# Altium schematic sheet Sensors.SchDoc
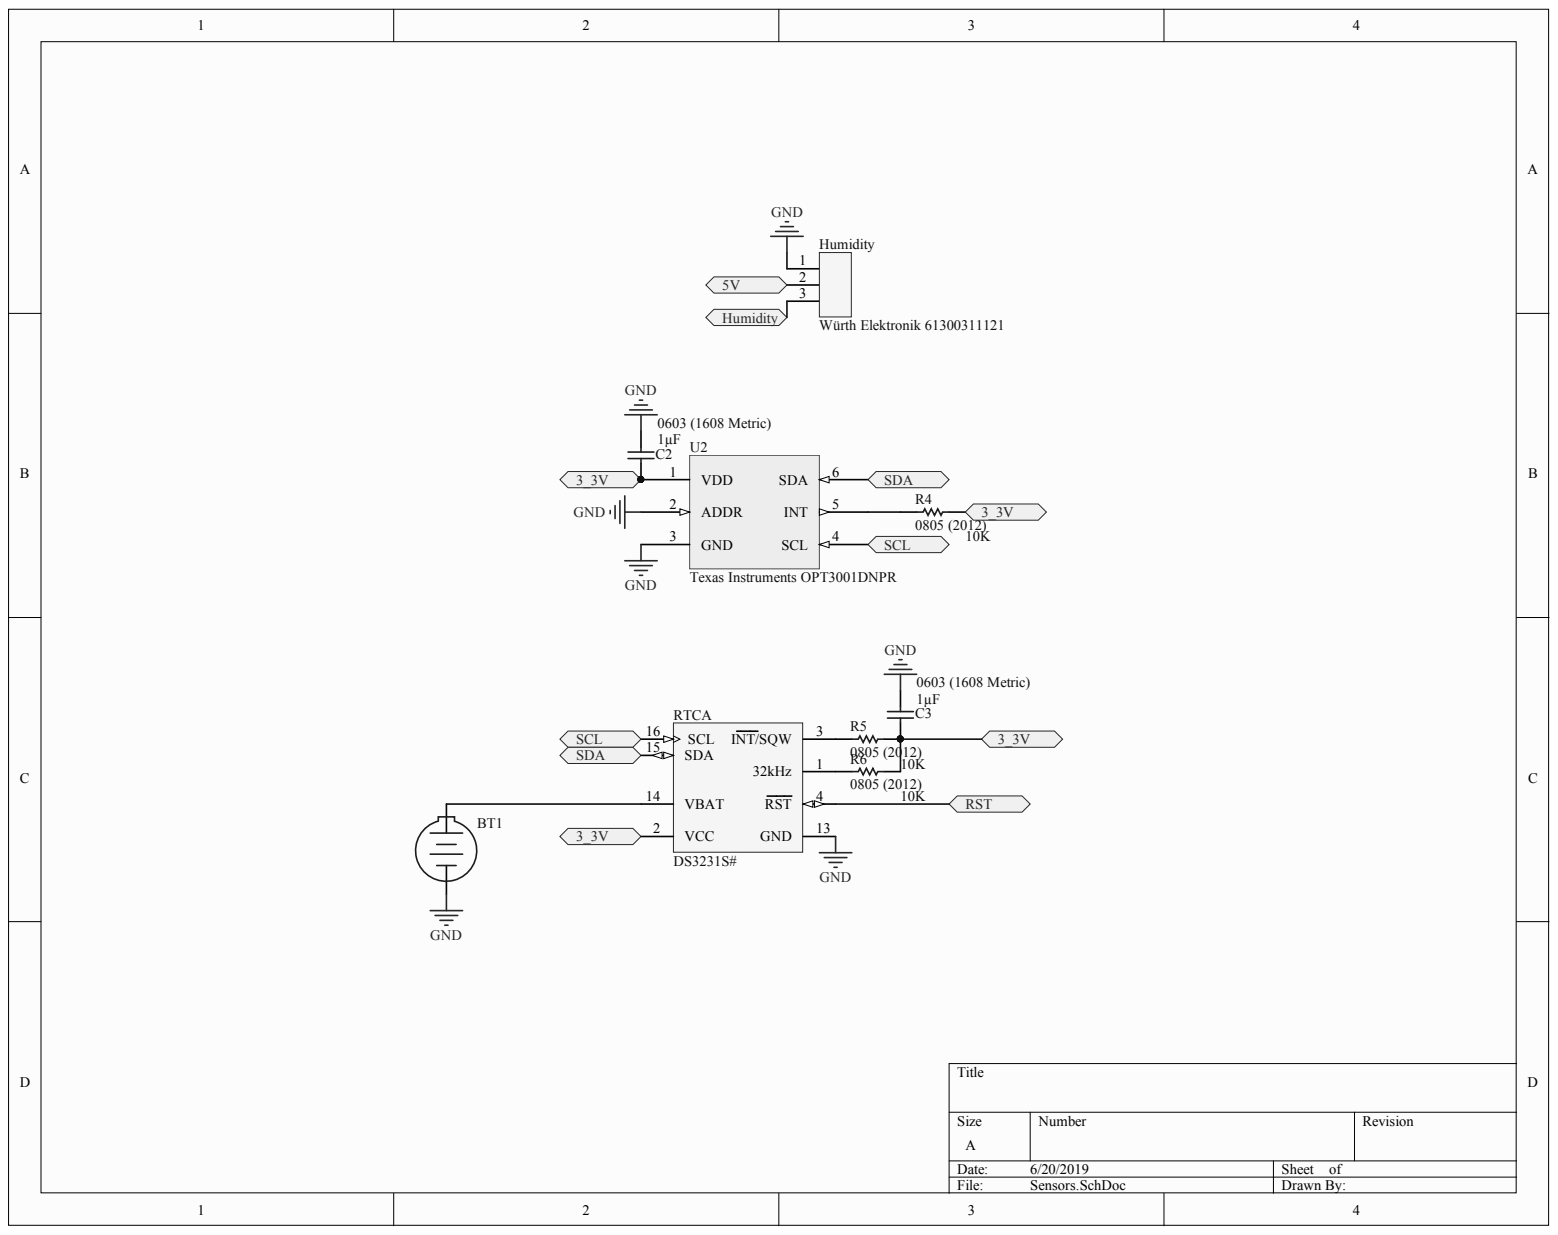
[diagram p0: Sensors.SchDoc]

## Parts
BT1  SYM-51b498be44848e73-1  [PCB-rukrbiajq2v9otngsupp-1]  (value not drawn)
C2  Capacitance: 1µF  [PCB-lkmleyt60a11wkc2qm7q-1]  (hidden comment: Samsung CL10A105KP8NNNC)
C3  Capacitance: 1µF  [PCB-lkmleyt60a11wkc2qm7q-1]  (hidden comment: Samsung CL10A105KP8NNNC)
Humidity  Würth Elektronik 61300311121  [PCB-4c070sxu9rgckamhssqs-1]
R4  0805 (2012)  Resistance: 10K  [PCB-m3d1bui9az782fo214h9-1]
R5  0805 (2012)  [PCB-m3d1bui9az782fo214h9-1]
R6  0805 (2012)  Resistance: 10K  [PCB-m3d1bui9az782fo214h9-1]
RTC  DS3231S#  [W16#H2_L]  (part A)
U2  Texas Instruments OPT3001DNPR  Manufacturer Part Number: OPT3001DNPR  [SOP6]

## Ports
3_3V, 5V, HUMIDITY, RST, SCL, SDA

## Nets
GND: BT1.2 C2.2 C3.2 Humidity.1 RTC.13(GND) U2.2(ADDR) U2.3(GND) U2.7
HUMIDITY: Humidity.3 ; port HUMIDITY
3_3V: C2.1 C3.1 R4.2 R5.2 R6.2 RTC.2(VCC) U2.1(VDD) ; port 3_3V
5V: Humidity.2 ; port 5V
RST: RTC.4(RST) ; port RST
SCL: RTC.16(SCL) U2.4(SCL) ; port SCL
SDA: RTC.15(SDA) U2.6(SDA) ; port SDA
NetBT1_1: BT1.1 RTC.14(VBAT)
NetR4_1: R4.1 U2.5(INT)
NetR5_1: R5.1 RTC.3(INT/SQW)
NetR6_1: R6.1 RTC.1(32kHz)

## Library data of the parts
BT1 = CMP-51b498be44848e73-1: Cordless Power Precision Screwdriver with Six 3/16" Drive Precision Bits, Uses 2 AAA Batteries; Wire Gauge: 2.00 AWG; Component Kind: Standard; Library Name: CommunityVault; Mounting Style: Surface Mount, Through Hole
C2 C3 = CMP-1956d172a695c691-3: CL10 Series 1 uF 10 V ±10 % Tolerance X5R SMT Multilayer Ceramic Capacitor; Manufacturer: Samsung Electro-Mechanics; Vendor Part Number: 1276-1182-1-ND; Tolerance: ±10%; Vendor: Digikey; Voltage - Rated: 10V; Applications: General Purpose; Component Kind: Standard; Cost: 0.10; HelpURL: http://www.samsungsem.com/kr/support/product-search/mlcc/__icsFiles/afieldfile/2016/08/18/S_CL10A105KP8NNNC.pdf; Library Name: CommunityVault; Manufacturer PArt Number: CL10A105KP8NNNC; Mounting Type: Surface Mount, MLCC; Operating Temperature: -55°C ~ 85°C; Package / Case: 0603 (1608 Metric); Series: CL; Size / Dimension: 0.063" L x 0.032" W (1.60mm x 0.80mm); Temperature Coefficient: X5R; Thickness (Max): 0.035" (0.90mm)
Humidity = CMP-8a90426ffa175557-21: Board-To-Board Connector, Vertical, Wr-Phd Series, Through Hole, Header, 3, 2.54 Mm; Number of Contacts: 3; Color: Black; Component Kind: Standard; Contact Material: Copper; Contact Plating: Gold; Library Name: CommunityVault; Manufacturer Part Number: 61300311121; OctopartID: 8a90426ffa175557; Octopart URL: https://octopart.com/61300311121-w%C3%BCrth+elektronik-32855521
R4 R6 = CMP-wcd0num848d6j3qzthhk-1: Generic Resistor pad 0805 Imperial and 2012 Metric; Case/Package: 0805 (2012); Component Kind: Standard; CustomComponentID: wcd0num848d6j3qzthhk; Library Name: CommunityVault
R5 = CMP-wcd0num848d6j3qzthhk-1: Generic Resistor pad 0805 Imperial and 2012 Metric; Resistance: 10K; Case/Package: 0805 (2012); Component Kind: Standard; CustomComponentID: wcd0num848d6j3qzthhk; Library Name: CommunityVault
RTC = CMP-1020750-2: Extremely Accurate I2C-Integrated Real-Time Clock/TCXO/Crystal, 16-Pin SO, 0 to 70 degC, RoHS Qualified; Case/Package: SOIC; Component Kind: Standard; Library Name: CommunityVault; Lifecycle Status: Active; Manufacturer Part Number: DS3231S#; Mounting Style: Surface Mount; Number of Pins: 16; OctopartID: 95a25cd540884a65; Octopart Url: https://octopart.com/ds3231s%23-maxim+integrated-8253516; Packaging: Tube; RoHS: Compliant
U2 = CMP-7afcb1244408a53b-9: Digital Ambient Light Sensor (ALS) with High Precision Human Eye Response 6-USON -40 to 85; Lead-Free Status: Lead Free; Component Kind: Standard; Library Name: CommunityVault; Lifecycle Status: Active; OctopartID: 7afcb1244408a53b; Octopart URL: http://octopart.com/opt3001dnpr-texas+instruments-47324050; RoHS: Compliant
